# Altium schematic sheet MCU_PORTS.SchDoc(MCU_PORTS)
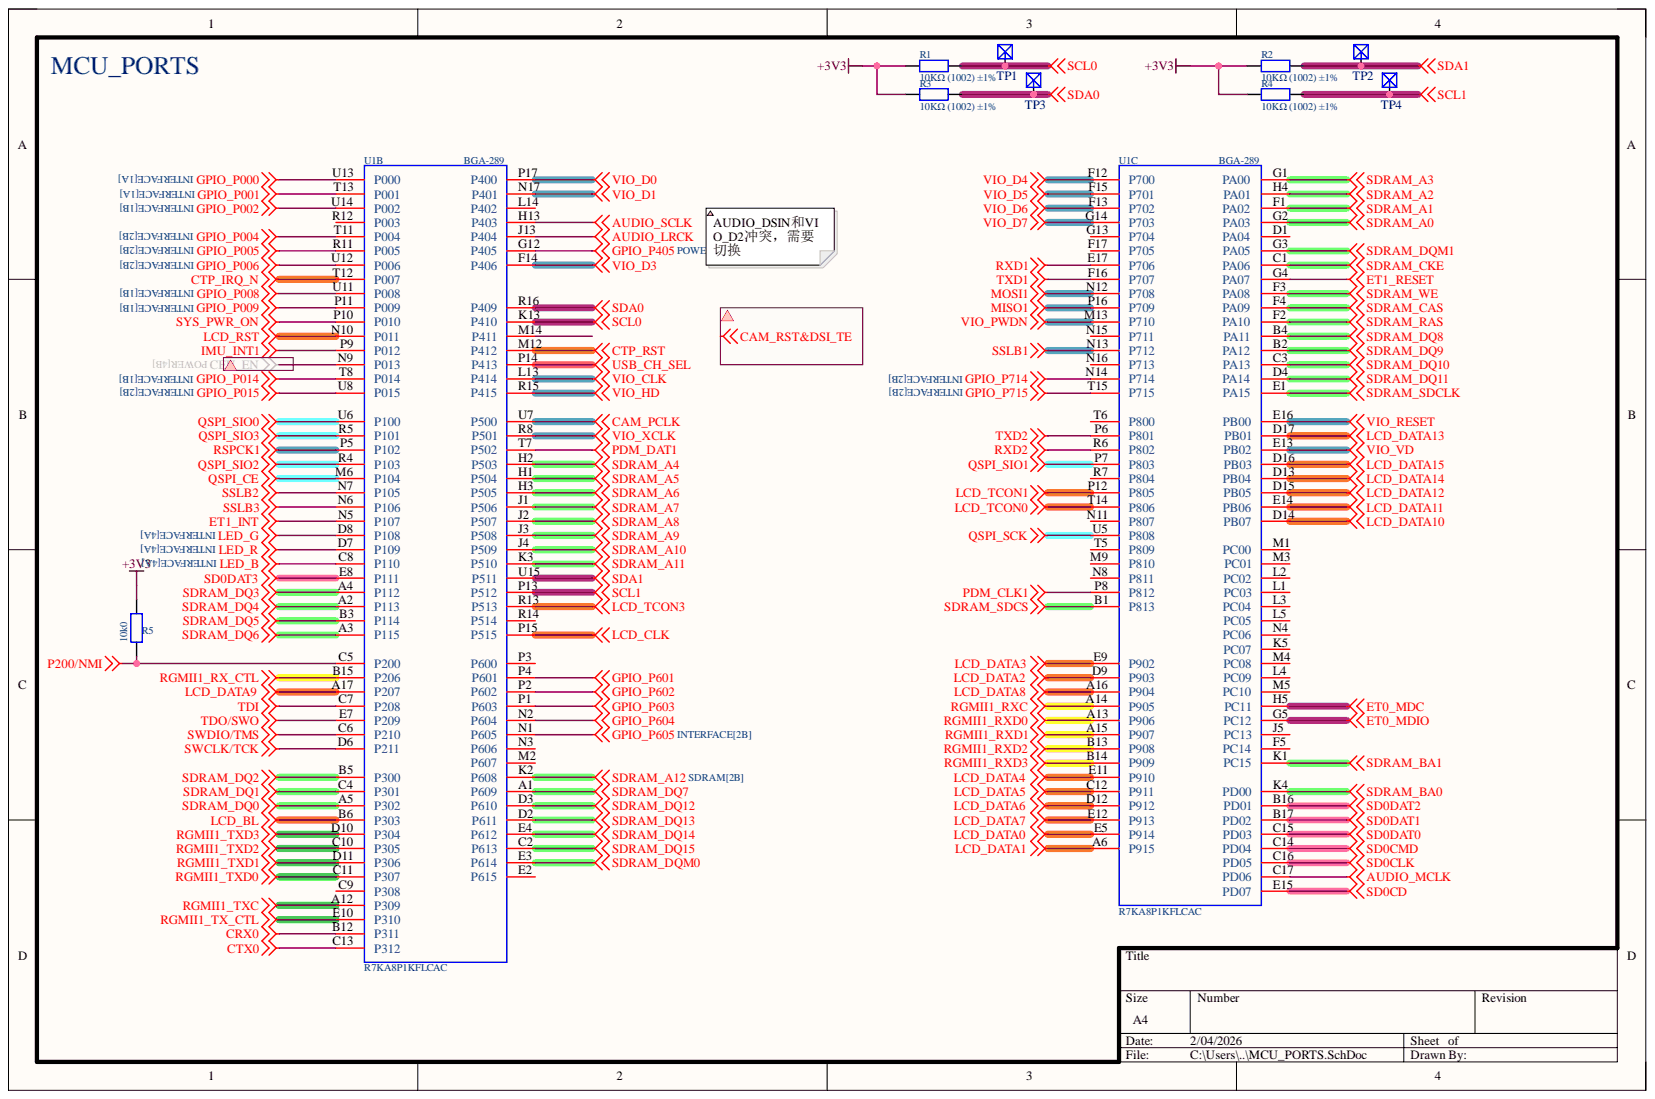
[diagram p0: MCU_PORTS.SchDoc]

## Parts
R1  10KΩ (1002) ±1%  [R0402]
R2  10KΩ (1002) ±1%  [R0402]
R3  10KΩ (1002) ±1%  [R0402]
R4  10KΩ (1002) ±1%  [R0402]
R5  10k0  [R0402]
TP1  TEST POINT  [TP_M_0.5]  (value not drawn)
TP2  TEST POINT  [TP_M_0.5]  (value not drawn)
TP3  TEST POINT  [TP_M_0.5]  (value not drawn)
TP4  TEST POINT  [TP_M_0.5]  (value not drawn)
U1  Manufacturer Part Number 1: R7KA8P1KFLCAC  Package: BGA-289  [RENESAS_P289F1-65-EAT_BGA-289]  (hidden comment: ICN-100026-01)  (parts B,C)

## Nets
+3V3: R1.2 R2.2 R3.2 R4.2 R5.2
AUDIO_LRCK: U1.J13(P404)
AUDIO_MCLK: U1.C17(PD06)
AUDIO_SCLK: U1.H13(P403)
CAM_PCLK: U1.U7(P500)
CRX0: U1.B12(P311)
CTP_IRQ_N: U1.T12(P007)
CTP_RST: U1.M12(P412)
CTX0: U1.C13(P312)
ET0_MDC: U1.H5(PC11)
ET0_MDIO: U1.G5(PC12)
ET1_INT: U1.N5(P107)
ET1_RESET: U1.G4(PA07)
GPIO_P000: U1.U13(P000)
GPIO_P001: U1.T13(P001)
GPIO_P002: U1.U14(P002)
GPIO_P004: U1.T11(P004)
GPIO_P005: U1.R11(P005)
GPIO_P006: U1.U12(P006)
GPIO_P008: U1.U11(P008)
GPIO_P009: U1.P11(P009)
GPIO_P014: U1.T8(P014)
GPIO_P015: U1.U8(P015)
GPIO_P405: U1.G12(P405)
GPIO_P601: U1.P4(P601)
GPIO_P602: U1.P2(P602)
GPIO_P603: U1.P1(P603)
GPIO_P604: U1.N2(P604)
GPIO_P605: U1.N1(P605)
GPIO_P714: U1.N14(P714)
GPIO_P715: U1.T15(P715)
IMU_INT1: U1.P9(P012)
LCD_BL: U1.B6(P303)
LCD_CLK: U1.P15(P515)
LCD_DATA0: U1.E5(P914)
LCD_DATA1: U1.A6(P915)
LCD_DATA2: U1.D9(P903)
LCD_DATA3: U1.E9(P902)
LCD_DATA4: U1.E11(P910)
LCD_DATA5: U1.C12(P911)
LCD_DATA6: U1.D12(P912)
LCD_DATA7: U1.E12(P913)
LCD_DATA8: U1.A16(P904)
LCD_DATA9: U1.A17(P207)
LCD_DATA10: U1.D14(PB07)
LCD_DATA11: U1.E14(PB06)
LCD_DATA12: U1.D15(PB05)
LCD_DATA13: U1.D17(PB01)
LCD_DATA14: U1.D13(PB04)
LCD_DATA15: U1.D16(PB03)
LCD_RST: U1.N10(P011)
LCD_TCON0: U1.T14(P806)
LCD_TCON1: U1.P12(P805)
LCD_TCON3: U1.R13(P513)
LED_B: U1.C8(P110)
LED_G: U1.D8(P108)
LED_R: U1.D7(P109)
MISO1: U1.P16(P709)
MOSI1: U1.N12(P708)
P200/NMI: R5.1 U1.C5(P200)
PDM_CLK1: U1.P8(P812)
PDM_DAT1: U1.T7(P502)
QSPI_CE: U1.M6(P104)
QSPI_SCK: U1.U5(P808)
QSPI_SIO0: U1.U6(P100)
QSPI_SIO1: U1.P7(P803)
QSPI_SIO2: U1.R4(P103)
QSPI_SIO3: U1.R5(P101)
RGMII1_RX_CTL: U1.B15(P206)
RGMII1_RXC: U1.A14(P905)
RGMII1_RXD0: U1.A13(P906)
RGMII1_RXD1: U1.A15(P907)
RGMII1_RXD2: U1.B13(P908)
RGMII1_RXD3: U1.B14(P909)
RGMII1_TX_CTL: U1.E10(P310)
RGMII1_TXC: U1.A12(P309)
RGMII1_TXD0: U1.C11(P307)
RGMII1_TXD1: U1.D11(P306)
RGMII1_TXD2: U1.C10(P305)
RGMII1_TXD3: U1.D10(P304)
RSPCK1: U1.P5(P102)
RXD1: U1.E17(P706)
RXD2: U1.R6(P802)
SCL0: R1.1 TP1.1 U1.K13(P410)
SCL1: R4.1 TP4.1 U1.P13(P512)
SD0CD: U1.E15(PD07)
SD0CLK: U1.C16(PD05)
SD0CMD: U1.C14(PD04)
SD0DAT0: U1.C15(PD03)
SD0DAT1: U1.B17(PD02)
SD0DAT2: U1.B16(PD01)
SD0DAT3: U1.E8(P111)
SDA0: R3.1 TP3.1 U1.R16(P409)
SDA1: R2.1 TP2.1 U1.U15(P511)
SDRAM_A0: U1.G2(PA03)
SDRAM_A1: U1.F1(PA02)
SDRAM_A2: U1.H4(PA01)
SDRAM_A3: U1.G1(PA00)
SDRAM_A4: U1.H2(P503)
SDRAM_A5: U1.H1(P504)
SDRAM_A6: U1.H3(P505)
SDRAM_A7: U1.J1(P506)
SDRAM_A8: U1.J2(P507)
SDRAM_A9: U1.J3(P508)
SDRAM_A10: U1.J4(P509)
SDRAM_A11: U1.K3(P510)
SDRAM_A12: U1.K2(P608)
SDRAM_BA0: U1.K4(PD00)
SDRAM_BA1: U1.K1(PC15)
SDRAM_CAS: U1.F4(PA09)
SDRAM_CKE: U1.C1(PA06)
SDRAM_DQ0: U1.A5(P302)
SDRAM_DQ1: U1.C4(P301)
SDRAM_DQ2: U1.B5(P300)
SDRAM_DQ3: U1.A4(P112)
SDRAM_DQ4: U1.A2(P113)
SDRAM_DQ5: U1.B3(P114)
SDRAM_DQ6: U1.A3(P115)
SDRAM_DQ7: U1.A1(P609)
SDRAM_DQ8: U1.B4(PA11)
SDRAM_DQ9: U1.B2(PA12)
SDRAM_DQ10: U1.C3(PA13)
SDRAM_DQ11: U1.D4(PA14)
SDRAM_DQ12: U1.D3(P610)
SDRAM_DQ13: U1.D2(P611)
SDRAM_DQ14: U1.E4(P612)
SDRAM_DQ15: U1.C2(P613)
SDRAM_DQM0: U1.E3(P614)
SDRAM_DQM1: U1.G3(PA05)
SDRAM_RAS: U1.F2(PA10)
SDRAM_SDCLK: U1.E1(PA15)
SDRAM_SDCS: U1.B1(P813)
SDRAM_WE: U1.F3(PA08)
SSLB1: U1.N13(P712)
SSLB2: U1.N7(P105)
SSLB3: U1.N6(P106)
SWCLK/TCK: U1.D6(P211)
SWDIO/TMS: U1.C6(P210)
SYS_PWR_ON: U1.P10(P010)
TDI: U1.C7(P208)
TDO/SWO: U1.E7(P209)
TXD1: U1.F16(P707)
TXD2: U1.P6(P801)
USB_CH_SEL: U1.P14(P413)
VIO_CLK: U1.L13(P414)
VIO_D0: U1.P17(P400)
VIO_D1: U1.N17(P401)
VIO_D3: U1.F14(P406)
VIO_D4: U1.F12(P700)
VIO_D5: U1.F15(P701)
VIO_D6: U1.F13(P702)
VIO_D7: U1.G14(P703)
VIO_HD: U1.R15(P415)
VIO_PWDN: U1.M13(P710)
VIO_RESET: U1.E16(PB00)
VIO_VD: U1.E13(PB02)
VIO_XCLK: U1.R8(P501)
CAM_RST&DSI_TE:

Not connected: U1 C9(P308) D1(PA04) E2(P615) F5(PC14) F17(P705) G13(P704) J5(PC13) K5(PC07) L1(PC03) L2(PC02) L3(PC04) L4(PC09) L5(PC05) L14(P402) M1(PC00) M2(P607) M3(PC01) M4(PC08) M5(PC10) M9(P810) M14(P411) N3(P606) N4(PC06) N8(P811) N9(P013) N11(P807) N15(P711) N16(P713) P3(P600) R7(P804) R12(P003) R14(P514) T5(P809) T6(P800)

## Library data of the parts
R1-R4 = 0402 10KΩ (1002) 1%: 贴片电阻，10K; Component Kind: Standard; ComponentLink1Description: 供应商链接; ComponentLink1URL: https://item.szlcsc.com/26487.html?fromZone=l_b__%2522brand%2522&spm=sc.bid.xh1.zy.n&lcsc_vid=FANcVFBQFQMNX1cFFAdZVVZXEgdeAgdWFlQNUVAHT1ExVlNSRFhXVlJQQFlYVzsOAxUeFF5JWA0HFBBIBBsCBBcFWQIBCksEBQAAA0tfTk8GEwkK; Library Name: RA8P1.SCHLIB; Package: 0402; Supplier: LC; SuppliersPartNumber: C25744
R5 = RES-100099-01: 贴片电阻，10K; Manufacturer: Yageo; Manufacturer Part Number 1: RC0402FR-0710KP; Notes: ANY EQUIVALENT; Power: 1/16W; Tolerance: 1%; Component Kind: Standard; ComponentLink1Description: New link; ComponentLink1URL: http://www.szlcsc.com/product/details_26487.html; Library Name: Resistors.IntLib; Manufacturer 1: Yageo; Manufacturer 2: Samsung Electro-Mechanics; Manufacturer 3: Stackpole Electronics Inc.; Manufacturer Part Number 2: RC1005F103CS; Manufacturer Part Number 3: RMCF0402FT10K0; Mfg: Yageo; Mfg Part Number: RC0402FR-0710KP; Package: 0402; PN: RC0402FR-0710KP; Resistance: 10K0; Supplier 1: Digi-Key; Supplier 2: Digi-Key; Supplier 3: Digi-Key; Supplier Part Number 1: YAG1249CT-ND; Supplier Part Number 2: 1276-3431-1-ND; Supplier Part Number 3: RMCF0402FT10K0CT-ND
TP1-TP4 = TP: Component Kind: Standard; Library Name: RA8P1.SCHLIB
U1 = ICN-100026-01: Renesas RA8P1 32-bit MCU BGA-289 12x12mm 0.65mm pitch; Architecture: 32bits; Code Flash: 1MB; Max Clock Speed: 1GHz; Notes: MUST USE SPECIFIC ?? FREE ISSUE ??; SRAM: 2MB; ClassName: BGA_MCU; Component Kind: Standard; Library Name: RA8P1.SCHLIB; Manufacturer: Renesas; Manufacturer 1: Renesas; Mfg: Renesas; Mfg Part Number: R7KA8P1KFLCAC; PN: R7KA8P1KFLCAC
